# Altium schematic sheet MCU.SchDoc(MCU)
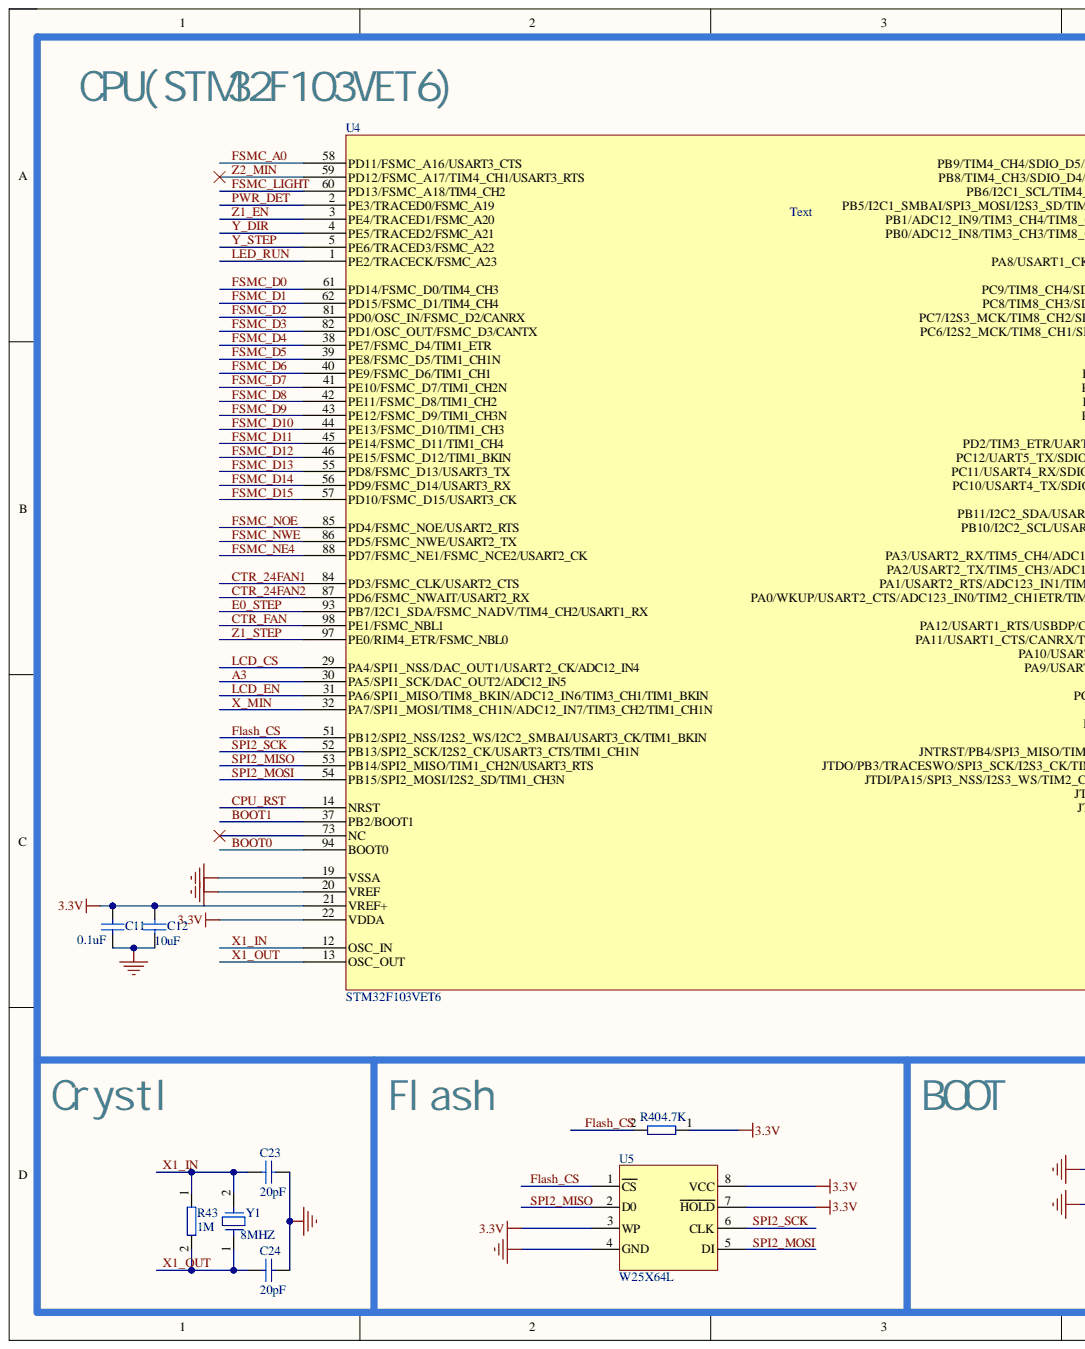
[diagram p0: MCU.SchDoc, left]
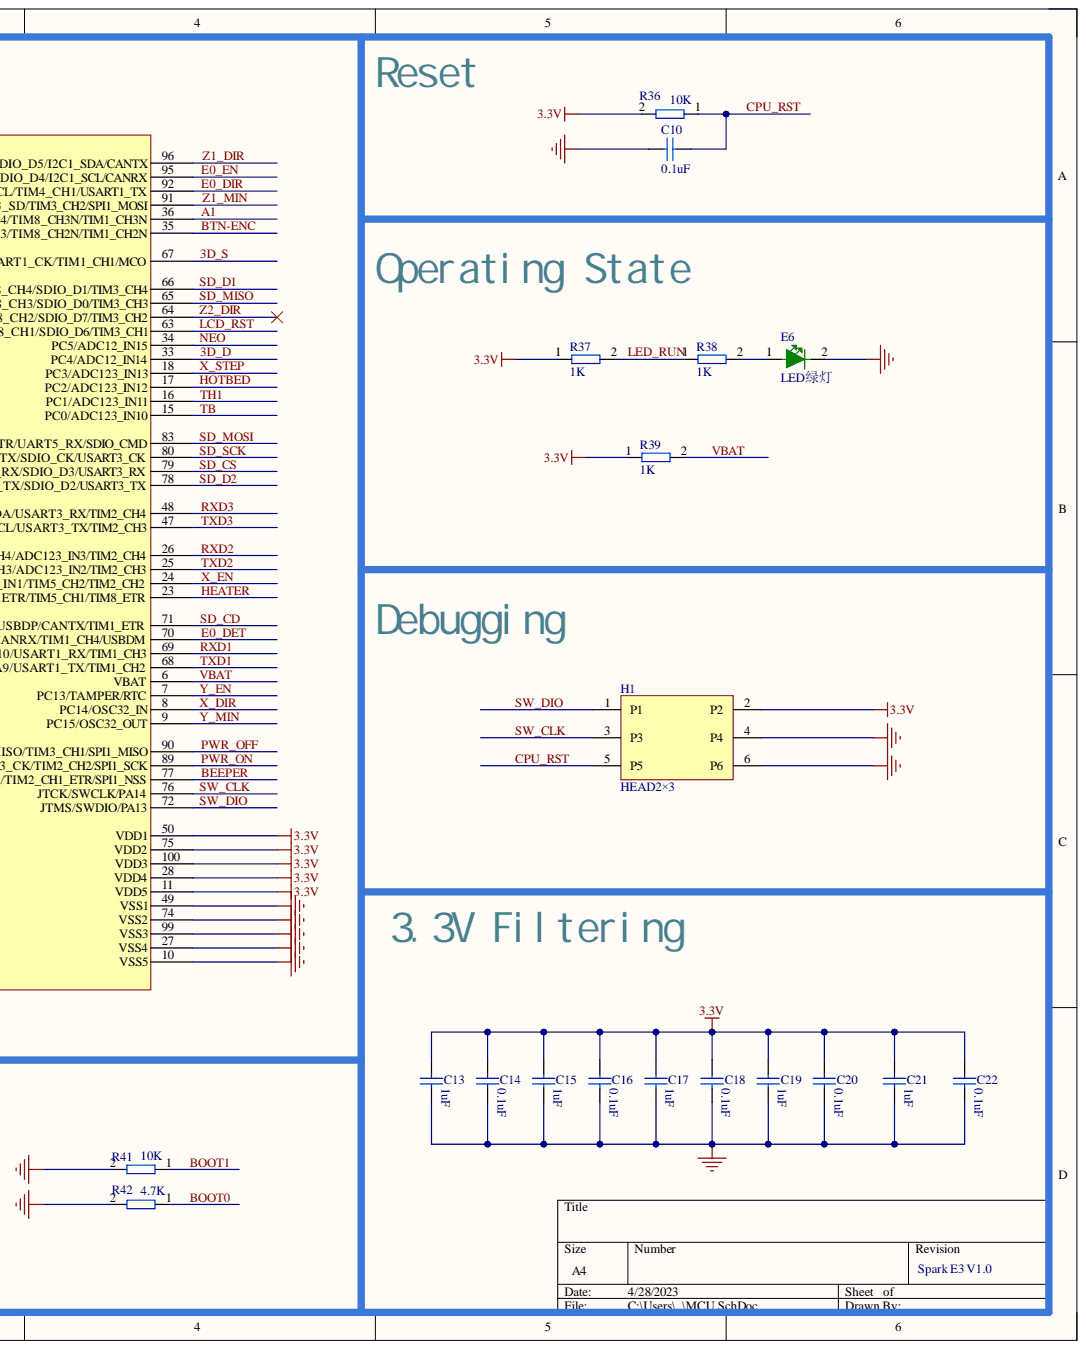
[diagram p1: MCU.SchDoc, right]

## Parts
C10  0.1uF  [C0603]
C11  0.1uF  [C0603]
C12  10uF  [C0805]
C13  1uF  [C0603]
C14  0.1uF  [C0603]
C15  1uF  [C0603]
C16  0.1uF  [C0603]
C17  1uF  [C0603]
C18  0.1uF  [C0603]
C19  1uF  [C0603]
C20  0.1uF  [C0603]
C21  1uF  [C0603]
C22  0.1uF  [C0603]
C23  20pF  [C0603]
C24  20pF  [C0603]
E6  LED绿灯  [0603 - LED-G]
H1  HEAD2×3  [双排针/2.54/3]
R36  10K  [R0603]
R37  1K  [R0603]
R38  1K  [R0603]
R39  1K  [R0603]
R40  4.7K  [R0603]  (value not drawn)
R41  10K  [R0603]
R42  4.7K  [R0603]
R43  1M  [R0603]
U4  STM32F103VET6  [LQFP-100]
U5  W25X64L  [SOP8W]
Y1  8MHZ  [CRY/5.08MM/H]

## Nets
3.3V: C11.1 C12.1 C13.1 C14.1 C15.1 C16.1 C17.1 C18.1 C19.1 C20.1 C21.1 C22.1 H1.2(P2) R36.2 R37.1 R39.1 R40.1 U4.11(VDD5) U4.21(VREF+) U4.22(VDDA) U4.28(VDD4) U4.50(VDD1) U4.75(VDD2) U4.100(VDD3) U5.3(WP) U5.7(HOLD) U5.8(VCC)
GND: C10.1 C11.2 C12.2 C13.2 C14.2 C15.2 C16.2 C17.2 C18.2 C19.2 C20.2 C21.2 C22.2 C23.1 C24.1 E6.2 H1.4(P4) H1.6(P6) R41.2 R42.2 U4.10(VSS5) U4.19(VSSA) U4.20(VREF) U4.27(VSS4) U4.49(VSS1) U4.74(VSS2) U4.99(VSS3) U5.4(GND)
3D_D: U4.33(PC4/ADC12_IN14)
3D_S: U4.67(PA8/USART1_CK/TIM1_CH1/MCO)
A1: U4.36(PB1/ADC12_IN9/TIM3_CH4/TIM8_CH3N/TIM1_CH3N)
A3: U4.30(PA5/SPI1_SCK/DAC_OUT2/ADC12_IN5)
BEEPER: U4.77(JTDI/PA15/SPI3_NSS/I2S3_WS/TIM2_CH1_ETR/SPI1_NSS)
BOOT0: R42.1 U4.94(BOOT0)
BOOT1: R41.1 U4.37(PB2/BOOT1)
BTN-ENC: U4.35(PB0/ADC12_IN8/TIM3_CH3/TIM8_CH2N/TIM1_CH2N)
CPU_RST: C10.2 H1.5(P5) R36.1 U4.14(NRST)
CTR_24FAN1: U4.84(PD3/FSMC_CLK/USART2_CTS)
CTR_24FAN2: U4.87(PD6/FSMC_NWAIT/USART2_RX)
CTR_FAN: U4.98(PE1/FSMC_NBL1)
E0_DET: U4.70(PA11/USART1_CTS/CANRX/TIM1_CH4/USBDM)
E0_DIR: U4.92(PB6/I2C1_SCL/TIM4_CH1/USART1_TX)
E0_EN: U4.95(PB8/TIM4_CH3/SDIO_D4/I2C1_SCL/CANRX)
E0_STEP: U4.93(PB7/I2C1_SDA/FSMC_NADV/TIM4_CH2/USART1_RX)
Flash_CS: R40.2 U4.51(PB12/SPI2_NSS/I2S2_WS/I2C2_SMBAI/USART3_CK/TIM1_BKIN) U5.1(CS)
FSMC_A0: U4.58(PD11/FSMC_A16/USART3_CTS)
FSMC_D0: U4.61(PD14/FSMC_D0/TIM4_CH3)
FSMC_D1: U4.62(PD15/FSMC_D1/TIM4_CH4)
FSMC_D2: U4.81(PD0/OSC_IN/FSMC_D2/CANRX)
FSMC_D3: U4.82(PD1/OSC_OUT/FSMC_D3/CANTX)
FSMC_D4: U4.38(PE7/FSMC_D4/TIM1_ETR)
FSMC_D5: U4.39(PE8/FSMC_D5/TIM1_CH1N)
FSMC_D6: U4.40(PE9/FSMC_D6/TIM1_CH1)
FSMC_D7: U4.41(PE10/FSMC_D7/TIM1_CH2N)
FSMC_D8: U4.42(PE11/FSMC_D8/TIM1_CH2)
FSMC_D9: U4.43(PE12/FSMC_D9/TIM1_CH3N)
FSMC_D10: U4.44(PE13/FSMC_D10/TIM1_CH3)
FSMC_D11: U4.45(PE14/FSMC_D11/TIM1_CH4)
FSMC_D12: U4.46(PE15/FSMC_D12/TIM1_BKIN)
FSMC_D13: U4.55(PD8/FSMC_D13/USART3_TX)
FSMC_D14: U4.56(PD9/FSMC_D14/USART3_RX)
FSMC_D15: U4.57(PD10/FSMC_D15/USART3_CK)
FSMC_LIGHT: U4.60(PD13/FSMC_A18/TIM4_CH2)
FSMC_NE4: U4.88(PD7/FSMC_NE1/FSMC_NCE2/USART2_CK)
FSMC_NOE: U4.85(PD4/FSMC_NOE/USART2_RTS)
FSMC_NWE: U4.86(PD5/FSMC_NWE/USART2_TX)
HEATER: U4.23(PA0/WKUP/USART2_CTS/ADC123_IN0/TIM2_CH1ETR/TIM5_CH1/TIM8_ETR)
HOTBED: U4.17(PC2/ADC123_IN12)
LCD_CS: U4.29(PA4/SPI1_NSS/DAC_OUT1/USART2_CK/ADC12_IN4)
LCD_EN: U4.31(PA6/SPI1_MISO/TIM8_BKIN/ADC12_IN6/TIM3_CH1/TIM1_BKIN)
LCD_RST: U4.63(PC6/I2S2_MCK/TIM8_CH1/SDIO_D6/TIM3_CH1)
LED_RUN: R37.2 R38.1 U4.1(PE2/TRACECK/FSMC_A23)
NEO: U4.34(PC5/ADC12_IN15)
PWR_DET: U4.2(PE3/TRACED0/FSMC_A19)
PWR_OFF: U4.90(JNTRST/PB4/SPI3_MISO/TIM3_CH1/SPI1_MISO)
PWR_ON: U4.89(JTDO/PB3/TRACESWO/SPI3_SCK/I2S3_CK/TIM2_CH2/SPI1_SCK)
RXD1: U4.69(PA10/USART1_RX/TIM1_CH3)
RXD2: U4.26(PA3/USART2_RX/TIM5_CH4/ADC123_IN3/TIM2_CH4)
RXD3: U4.48(PB11/I2C2_SDA/USART3_RX/TIM2_CH4)
SD_CD: U4.71(PA12/USART1_RTS/USBDP/CANTX/TIM1_ETR)
SD_CS: U4.79(PC11/USART4_RX/SDIO_D3/USART3_RX)
SD_D1: U4.66(PC9/TIM8_CH4/SDIO_D1/TIM3_CH4)
SD_D2: U4.78(PC10/USART4_TX/SDIO_D2/USART3_TX)
SD_MISO: U4.65(PC8/TIM8_CH3/SDIO_D0/TIM3_CH3)
SD_MOSI: U4.83(PD2/TIM3_ETR/UART5_RX/SDIO_CMD)
SD_SCK: U4.80(PC12/UART5_TX/SDIO_CK/USART3_CK)
SPI2_MISO: U4.53(PB14/SPI2_MISO/TIM1_CH2N/USART3_RTS) U5.2(D0)
SPI2_MOSI: U4.54(PB15/SPI2_MOSI/I2S2_SD/TIM1_CH3N) U5.5(DI)
SPI2_SCK: U4.52(PB13/SPI2_SCK/I2S2_CK/USART3_CTS/TIM1_CH1N) U5.6(CLK)
SW_CLK: H1.3(P3) U4.76(JTCK/SWCLK/PA14)
SW_DIO: H1.1(P1) U4.72(JTMS/SWDIO/PA13)
TB: U4.15(PC0/ADC123_IN10)
TH1: U4.16(PC1/ADC123_IN11)
TXD1: U4.68(PA9/USART1_TX/TIM1_CH2)
TXD2: U4.25(PA2/USART2_TX/TIM5_CH3/ADC123_IN2/TIM2_CH3)
TXD3: U4.47(PB10/I2C2_SCL/USART3_TX/TIM2_CH3)
VBAT: R39.2 U4.6(VBAT)
X1_IN: C23.2 R43.1 U4.12(OSC_IN) Y1.2
X1_OUT: C24.2 R43.2 U4.13(OSC_OUT) Y1.1
X_DIR: U4.8(PC14/OSC32_IN)
X_EN: U4.24(PA1/USART2_RTS/ADC123_IN1/TIM5_CH2/TIM2_CH2)
X_MIN: U4.32(PA7/SPI1_MOSI/TIM8_CH1N/ADC12_IN7/TIM3_CH2/TIM1_CH1N)
X_STEP: U4.18(PC3/ADC123_IN13)
Y_DIR: U4.4(PE5/TRACED2/FSMC_A21)
Y_EN: U4.7(PC13/TAMPER/RTC)
Y_MIN: U4.9(PC15/OSC32_OUT)
Y_STEP: U4.5(PE6/TRACED3/FSMC_A22)
Z1_DIR: U4.96(PB9/TIM4_CH4/SDIO_D5/I2C1_SDA/CANTX)
Z1_EN: U4.3(PE4/TRACED1/FSMC_A20)
Z1_MIN: U4.91(PB5/I2C1_SMBAI/SPI3_MOSI/I2S3_SD/TIM3_CH2/SPI1_MOSI)
Z1_STEP: U4.97(PE0/RIM4_ETR/FSMC_NBL0)
Z2_DIR: U4.64(PC7/I2S3_MCK/TIM8_CH2/SDIO_D7/TIM3_CH2)
Z2_MIN: U4.59(PD12/FSMC_A17/TIM4_CH1/USART3_RTS)
NetE6_1: E6.1 R38.2

Not connected: U4 73(NC)

## Library data of the parts
C10-C24 = 电容: Component Kind: Standard; LatestRevisionDate: 17-Jul-2002; LatestRevisionNote: Re-released for DXP Platform.; PackageDescription: Radial Cap, Thru-Hole; 2 Leads; 0.3 in Pin Spacing; PackageReference: RAD-0.3; Published: 8-Jun-2000; Signal Integrity: Cap; Simulation: CAP; Value: ?; Library Name: MYschlib.SchLib; Publisher: Altium Limited
R36-R43 = 电阻: Component Kind: Standard; value: ?; Library Name: MYschlib.SchLib
U4 = STM32F103VCT6: Component Kind: Standard; Library Name: jietai-ADLB.SchLib
U5 = W25X40L: Component Kind: Standard; Library Name: jietai-ADLB.SchLib
Y1 = 晶振/11.0592MHZ: Component Kind: Standard; VALUE: 11.0592MHZ; Library Name: MYschlib.SchLib
E6 = LED绿灯: Component Kind: Standard; Library Name: MYschlib.SchLib
H1 = HEAD2×3: Component Kind: Standard; Library Name: jietai-ADLB.SchLib
